# Altium schematic sheet Controleur.SchDoc(Controleur)
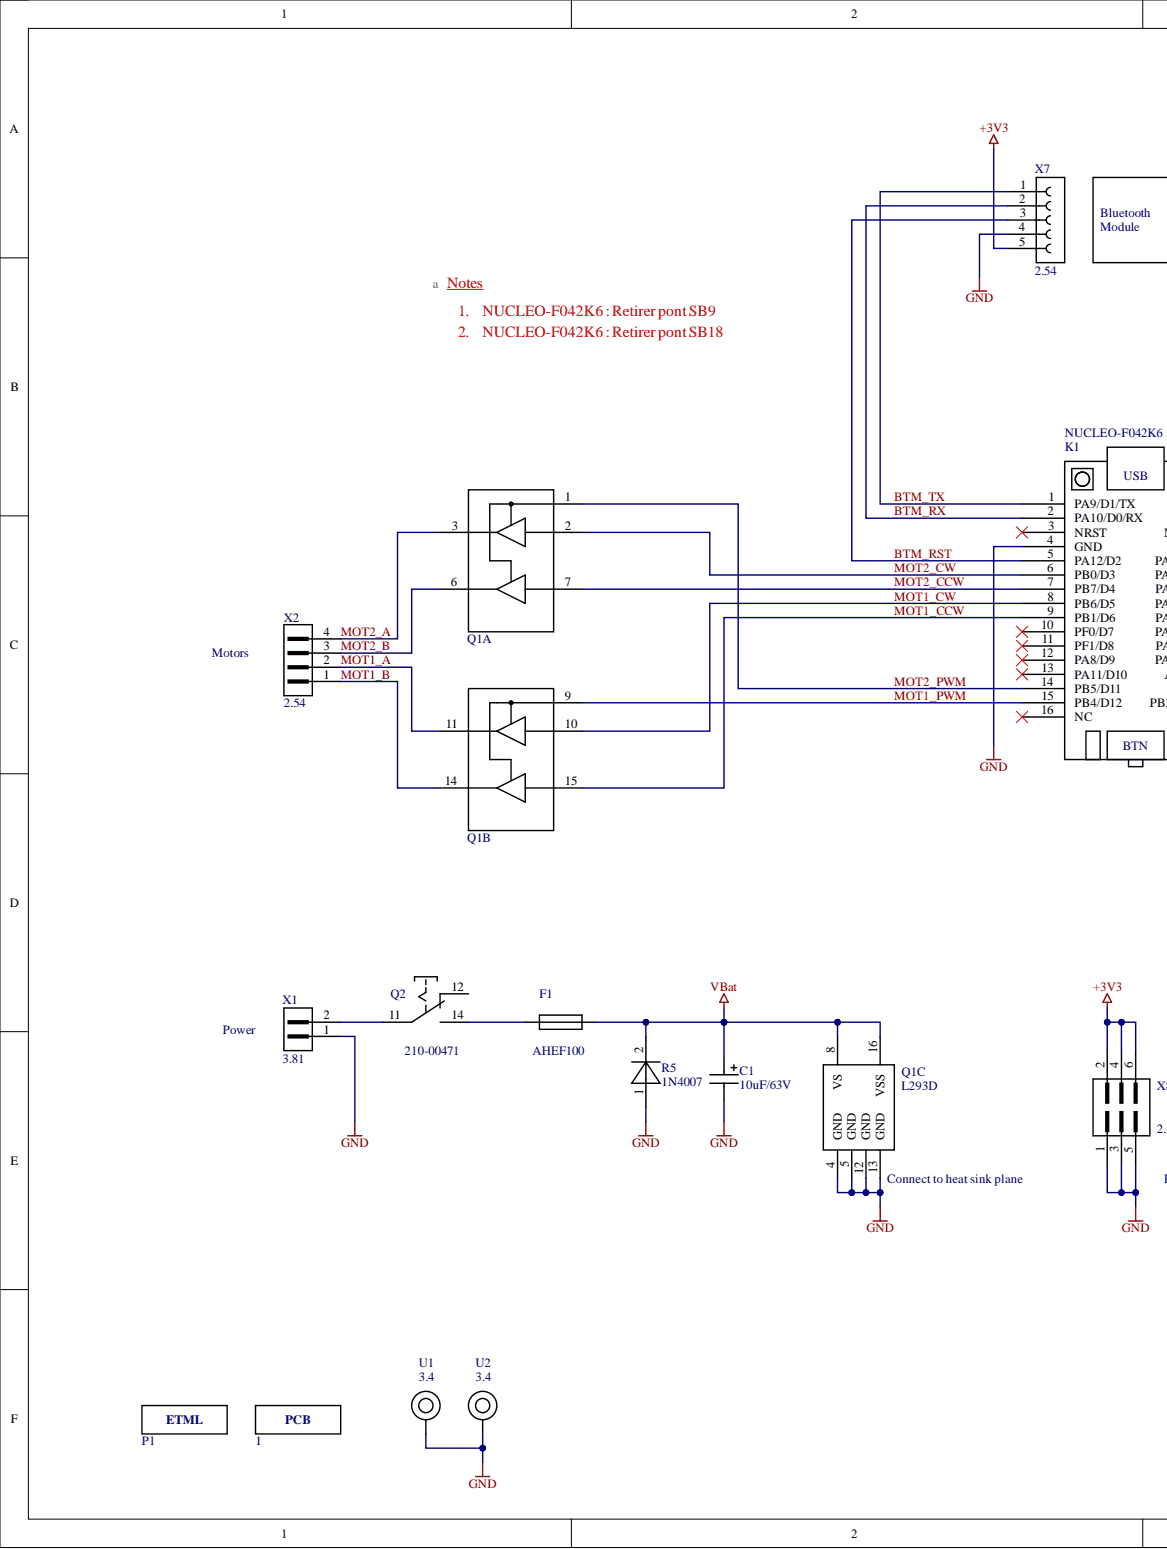
[diagram p0: Controleur.SchDoc, left]
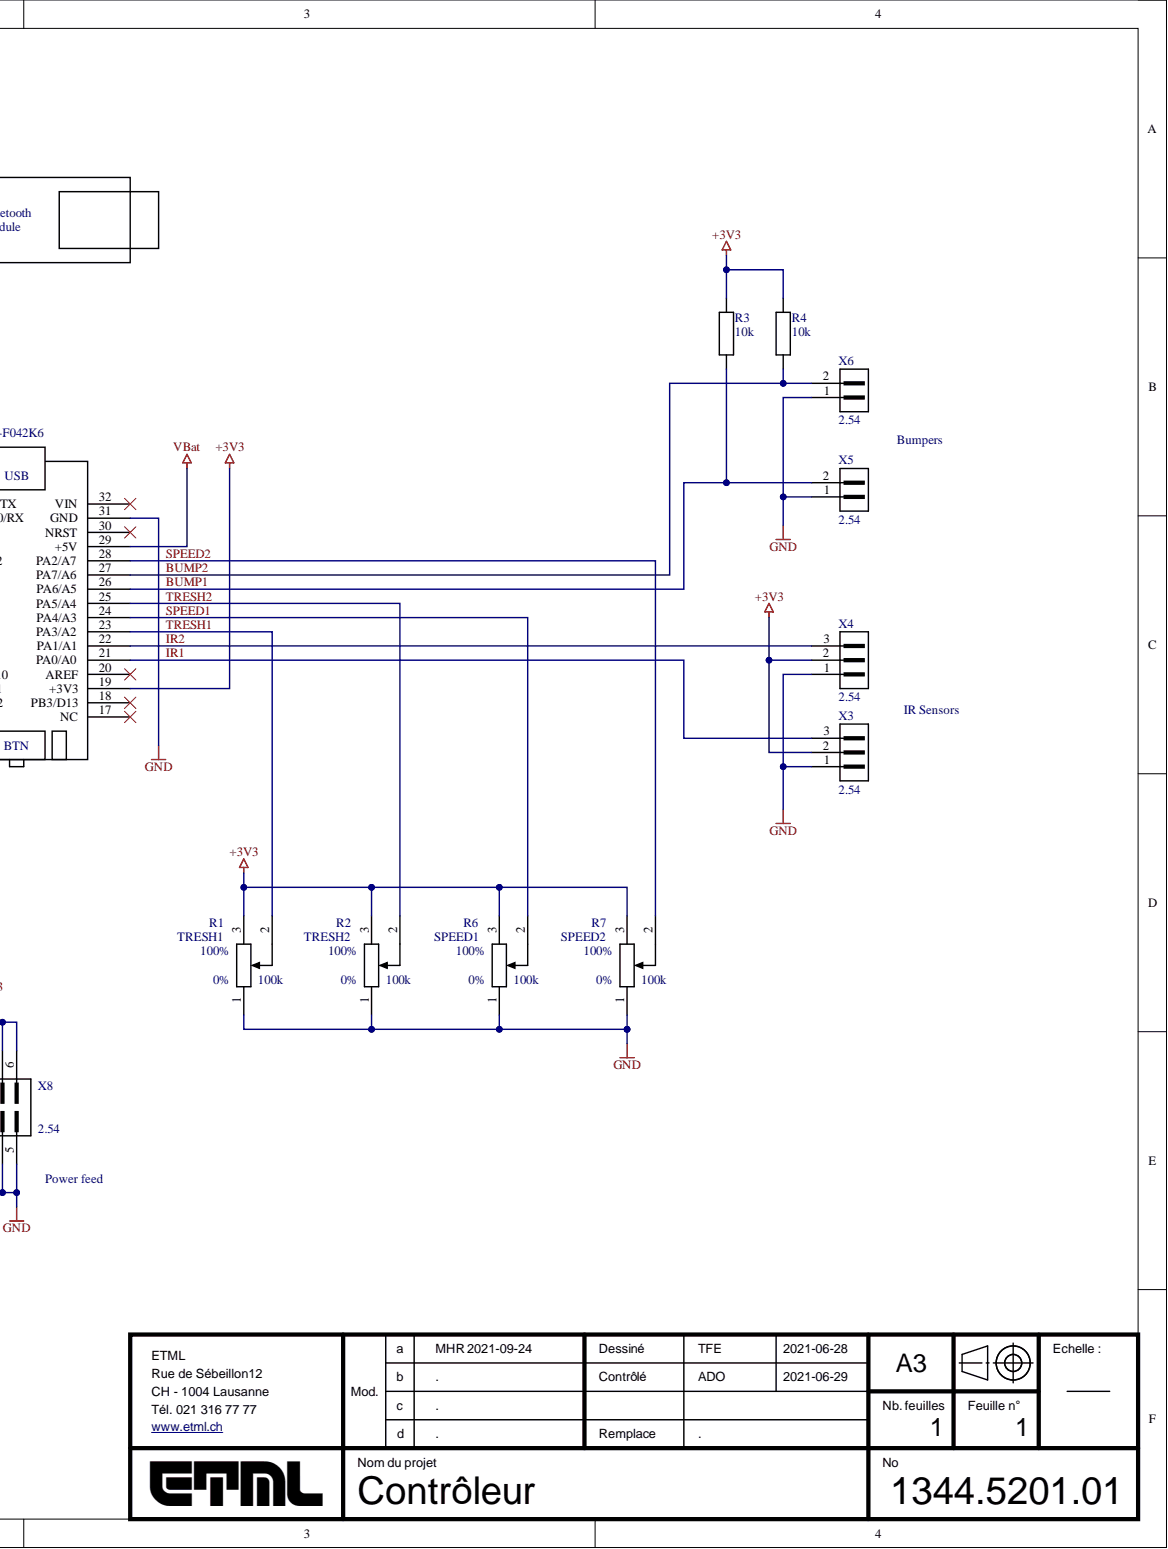
[diagram p1: Controleur.SchDoc, right]

## Parts
1  PCB  (mechanical)
C1  10uF/63V  [CAPPRD200W50D500H1100]
F1  AHEF100  [FUSAD583D970H1360]
K1  NUCLEO-F042K6  [STM32-NUCLEO32-MB1180]
P1  ETML-CU  [DRAW-ETML-CU]  (value not drawn)  (no BOM)
Q1  L293D  [DIP762W50P254L2000H510Q16]  (parts A,B,C)
Q2  210-00471  [RND_210-00471]
R1  100k  [Piher_PT-10_V10]
R2  100k  [Piher_PT-10_V10]
R3  10k  [RESAD1270W60L650D250]
R4  10k  [RESAD1270W60L650D250]
R5  1N4007  [DIOAD1016W86L520D270]
R6  100k  [Piher_PT-10_V10]
R7  100k  [Piher_PT-10_V10]
U1  3.4  [MECH-PHD340]  (no BOM)
U2  3.4  [MECH-PHD340]  (no BOM)
X1  3.81  [WE_691322310002]
X2  2.54  [PIN-1x04-2.54]
X3  2.54  [PIN-1x03-2.54]
X4  2.54  [PIN-1x03-2.54]
X5  2.54  [PIN-1x02-2.54]
X6  2.54  [PIN-1x02-2.54]
X7  2.54  [REC-1x05-2.54]
X8  2.54  [PIN-2x03-2.54]

## Nets
+3V3: K1.19(+3V3) R1.3 R2.3 R3.2 R4.2 R6.3 R7.3 X3.2 X4.2 X7.5 X8.2 X8.4 X8.6
GND: C1.2 K1.4(GND) K1.31(GND) Q1.4(GND) Q1.5(GND) Q1.12(GND) Q1.13(GND) R1.1 R2.1 R5.1 R6.1 R7.1 U1.1 U2.1 X1.1 X3.1 X4.1 X5.1 X6.1 X7.4 X8.1 X8.3 X8.5
VBat: C1.1 F1.2 K1.29(+5V) Q1.8(VS) Q1.16(VSS) R5.2
BTM_RST: K1.5(PA12/D2) X7.3
BTM_RX: K1.2(PA10/D0/RX) X7.2
BTM_TX: K1.1(PA9/D1/TX) X7.1
BUMP1: K1.26(PA6/A5) R3.1 X5.2
BUMP2: K1.27(PA7/A6) R4.1 X6.2
IR1: K1.21(PA0/A0) X3.3
IR2: K1.22(PA1/A1) X4.3
MOT1_A: Q1.11 X2.2
MOT1_B: Q1.14 X2.1
MOT1_CCW: K1.9(PB1/D6) Q1.15
MOT1_CW: K1.8(PB6/D5) Q1.10
MOT1_PWM: K1.15(PB4/D12) Q1.9
MOT2_A: Q1.3 X2.4
MOT2_B: Q1.6 X2.3
MOT2_CCW: K1.7(PB7/D4) Q1.7
MOT2_CW: K1.6(PB0/D3) Q1.2
MOT2_PWM: K1.14(PB5/D11) Q1.1
SPEED1: K1.24(PA4/A3) R6.2
SPEED2: K1.28(PA2/A7) R7.2
TRESH1: K1.23(PA3/A2) R1.2
TRESH2: K1.25(PA5/A4) R2.2
NetF1_1: F1.1 Q2.14
NetQ2_11: Q2.11 X1.2

Not connected: K1 3(NRST) 10(PF0/D7) 11(PF1/D8) 12(PA8/D9) 13(PA11/D10) 16(NC) 17(NC) 18(PB3/D13) 20(AREF) 30(NRST) 32(VIN); Q2 12

## Library data of the parts
1 = PN-108075: PCB element for BOM; Database Table Name: Parts; IsStocked: False; Value: PCB-BOM; Library Name: Parts.DbLib; PN: PN-108075; SubCategory: Dessins; Supplier 1: Eurocircuits Sàrl; Supplier Part Number 1: TD21.5101.00; Supplier Price 1: 2.33
C1 = PN-503157: CAP 10uF ALU 63V 20% Radial; Manufacturer: Nippon Chemi-Con; Manufacturer Number: ESMG630ELL100ME11D; Database Table Name: Parts; Dielectric: Al3O2; IsStocked: True; TemperatureRange: -40°C to +85°C; Component Kind: Standard; ComponentLink1Description: Nippon_Chemi-Con_SMG.pdf; ComponentLink1URL: Z:/Library/Datasheets/Nippon_Chemi-Con_SMG.pdf; Library Name: Parts.DbLib; PN: PN-503157; SubCategory: Condensateurs aluminium; Supplier 1: ETML; Supplier 1 Price: 0.00; Supplier Part Number 1: MAG.331.453; Supplier Price 1: 0,0422; Tolerance: ±20%; Value: 10uF; Voltage: 63V
F1 = PN-719111: FUSE PTC RESETTABLE 1.0A/1.9A  6.2s 32V RADIAL LEADES; Manufacturer: Littelfuse; Database Table Name: Parts; IsStocked: False; TemperatureRange: -40°C to +125°C; Component Kind: Standard; ComponentLink1Description: Littlefuse_PolySwitch.pdf; ComponentLink1URL: Z:/Library/Datasheets/Littlefuse_PolySwitch.pdf; Current: 1.0A/1.9A; Library Name: Parts.DbLib; Manufacturer Number: AHEF100; PN: PN-719111; SubCategory: Fusibles; Supplier 1: Farnell; Supplier Part Number 1: 2786603; Supplier Price 1: 0,663; Value: AHEF100; Voltage: 32V
K1 = PN-481028: MCU STM32F042K6 Nucleo-32; Manufacturer: STMicroelectronics; Database Table Name: Parts; IsStocked: False; Component Kind: Standard; ComponentLink1Description: STM32F042X4_STM32F042X6.pdf; ComponentLink1URL: Z:/Library/Datasheets/STM32F042X4_STM32F042X6.pdf; Library Name: Parts.DbLib; Manufacturer Number: NUCLEO-F042K6; PN: PN-481028; SubCategory: Microcontrôleur; Supplier 1: Farnell; Supplier 1 Price: 0.00; Supplier Part Number 1: 2500223; Supplier Price 1: 10,71; Value: NUCLEO-F042K6
P1 = PN-439033: Logo ETML; Database Table Name: Parts; IsStocked: False; Library Name: Parts.DbLib; PN: PN-439033; SubCategory: Dessins; Value: ETML-CU
Q1 = PN-242945: DRIVER L293D Push-pull 4 Channel  600mA DIP; Manufacturer: STMicroelectronics; Manufacturer Number: L296D; Database Table Name: Parts; IsStocked: False; Power: 4W; TemperatureRange: -40°C to +80°C; Voltage: 4.5V to 36V; Component Kind: Standard; ComponentLink1Description: STMicroelectronics_L293D.pdf; ComponentLink1URL: Z:/Library/Datasheets/STMicroelectronics_L293D.pdf; Current: 600mA; Library Name: Parts.DbLib; PN: PN-242945; SubCategory: Pilotes; Supplier 1: Distrelec AG; Supplier 1 Price: 0.00; Supplier Part Number 1: 173-13-224; Supplier Price 1: 2,34; Value: L293D
Q2 = PN-957194: SW SPDT 210-00471 28V 3A THT; Manufacturer: RND Components; Database Table Name: Parts; IsStocked: False; SDTSourceLibName: N:\APP\ORCADWIN\EXPRESS\LIBRARY\ETML_LIB\R_FILMME; TemperatureRange: -40°C to +160 °C; Voltage: 28VDC; Component Kind: Standard; ComponentLink1Description: RND_210-00471.pdf; ComponentLink1URL: Z:/Library/Datasheets/RND_210-00471.pdf; Current: 3A; Library Name: Parts.DbLib; Manufacturer Number: 210-00471; PN: PN-957194; SubCategory: Commutateurs; Supplier 1: Distrelec AG; Supplier Part Number 1: 301-03-456; Supplier Price 1: 1,99; Value: 210-00471
R1 R2 R6 R7 = PN-811986: RESADJ 100kohm Cermet 1 turn; Manufacturer: Piher; Manufacturer Number: PT10LV10104A2020S; Database Table Name: Parts; IsStocked: False; Power: 150mW; TemperatureCoeff: ±300ppm/°C; TemperatureRange: -25°C to +70°C; Tolerance: ±20%; Component Kind: Standard; ComponentLink1Description: Piher_PT-10.pdf; ComponentLink1URL: Z:/Library/Datasheets/Piher_PT-10.pdf; Library Name: Parts.DbLib; PN: PN-811986; SubCategory: Résistances ajustables; Supplier 1: Distrelec AG; Supplier Part Number 1: 164-36-273; Supplier Price 1: 0,4483; Value: 100k
R3 R4 = PN-518664: RES 10kohm  ±1% 0.6W  Axial; Manufacturer: Vishay; Manufacturer Number: MRS25000C1002FCT00; Database Table Name: Parts; IsStocked: True; TemperatureCoeff: ±50ppm/°C; TemperatureRange: -55°C to 155°C; Voltage: 350V; Component Kind: Standard; ComponentLink1Description: Vishay_MRS25.pdf; ComponentLink1URL: Z:/Library/Datasheets/Vishay_MRS25.pdf; Library Name: Parts.DbLib; PN: PN-518664; Power: 0.6W; SubCategory: Résistances film métallique; Supplier 1: ETML; Supplier Part Number 1: MAG.323.194; Supplier Price 1: 0,05; Tolerance: ±1%; Value: 10k
R5 = PN-172199: DIO 1N4007 0.8V 1A 1kV  Axial; Manufacturer: ON Semicoductor; Manufacturer Number: 1N4007RLG; Database Table Name: Parts; IsStocked: False; TemperatureRange: -65°C to +175°C; Component Kind: Standard; ComponentLink1Description: ONSemi_1N400X.pdf; ComponentLink1URL: Z:/Library/Datasheets/ONSemi_1N400X.pdf; Current: 1A; Library Name: Parts.DbLib; PN: PN-172199; SubCategory: Diodes; Supplier 1: Farnell; Supplier Part Number 1: 2317678; Supplier Price 1: 0,0239; Value: 1N4007; Voltage: 1kV
U1 U2 = PN-814249: Plated Hole  3.4mm; Database Table Name: Parts; IsStocked: False; Library Name: Parts.DbLib; PN: PN-814249; SubCategory: Mécaniques; Value: 3.4
X1 = PN-596032: TERM 1x02 3.81 H; Manufacturer: Würth Electronik; Manufacturer Number: 691322310002; Current: 10A; Database Table Name: Parts; Dielectric: PA66; IsStocked: True; System: Connector; TemperatureRange: -40°C to +105°C; Voltage: 300VAC; Component Kind: Standard; ComponentLink1Description: WE_6913223100XX.pdf; ComponentLink1URL: Z:/Library/Datasheets/WE_6913223100XX.pdf; Library Name: Parts.DbLib; PN: PN-596032; SubCategory: Borniers; Supplier 1: ETML; Supplier 1 Price: 0.00; Supplier Part Number 1: MAG.296.029; Supplier Price 1: 0,23; Value: 3.81
X2 = PN-579072: PIN HEADER 2.54 1x04; Manufacturer: Würth Electronik; Manufacturer Number: 61300411121; Current: 3A; Database Table Name: Parts; IsStocked: True; System: Connector; TemperatureRange: -40°C to +105°C; Voltage: 250V; Component Kind: Standard; ComponentLink1Description: WE_61300411121.pdf; ComponentLink1URL: Z:/Library/Datasheets/WE_61300411121.pdf; Library Name: Parts.DbLib; PN: PN-579072; SubCategory: Pin Header; Supplier 1: ETML; Supplier 1 Price: 0.00; Supplier Part Number 1: MAG.283.897; Supplier Price 1: 0,08; Value: 2.54
X3 X4 = PN-904861: PIN HEADER 2.54 1x03; Manufacturer: Würth Electronik; Manufacturer Number: 61300311121; Current: 3A; Database Table Name: Parts; IsStocked: True; System: Connector; TemperatureRange: -40°C to +105°C; Voltage: 250V; Component Kind: Standard; ComponentLink1Description: WE_61300411121.pdf; ComponentLink1URL: Z:/Library/Datasheets/WE_61300411121.pdf; Library Name: Parts.DbLib; PN: PN-904861; SubCategory: Pin Header; Supplier 1: ETML; Supplier 1 Price: 0.00; Supplier Part Number 1: MAG.283.897; Supplier Price 1: 0,06; Value: 2.54
X5 X6 = PN-573903: PIN HEADER 2.54 1x02; Manufacturer: Würth Electronik; Manufacturer Number: 61300211121; Current: 3A; Database Table Name: Parts; IsStocked: True; System: Connector; TemperatureRange: -40°C to +105°C; Voltage: 250V; Component Kind: Standard; ComponentLink1Description: WE_61300411121.pdf; ComponentLink1URL: Z:/Library/Datasheets/WE_61300411121.pdf; Library Name: Parts.DbLib; PN: PN-573903; SubCategory: Pin Header; Supplier 1: ETML; Supplier 1 Price: 0.00; Supplier Part Number 1: MAG.283.897; Supplier Price 1: 0,04; Value: 2.54
X7 = PN-150070: PIN RECEPTACLE 2.54 1x05; Manufacturer: Würth Electronik; Manufacturer Number: 61300511821; Current: 3A; Database Table Name: Parts; IsStocked: True; System: Connector; TemperatureRange: -40°C to +105°C; Voltage: 250V; Component Kind: Standard; ComponentLink1Description: WE_61300511821.pdf; ComponentLink1URL: Z:/Library/Datasheets/WE_61300511821.pdf; Library Name: Parts.DbLib; PN: PN-150070; SubCategory: Pin Receptacle; Supplier 1: Farnell; Supplier 1 Price: 0.00; Supplier Part Number 1: 2827891; Supplier Price 1: 0,375; Value: 2.54
X8 = PN-626236: PIN HEADER 2.54 2x03; Manufacturer: Würth Electronik; Manufacturer Number: 61300621121; Current: 3A; Database Table Name: Parts; IsStocked: False; System: Connector; TemperatureRange: -40°C to +105°C; Voltage: 250V; Component Kind: Standard; ComponentLink1Description: WE_61301021121.pdf; ComponentLink1URL: Z:/Library/Datasheets/WE_61301021121.pdf; Library Name: Parts.DbLib; PN: PN-626236; SubCategory: Pin Header; Supplier 1: Farnell; Supplier 1 Price: 0.00; Supplier Part Number 1: 2356131; Supplier Price 1: 0,473; Value: 2.54
